# Altium schematic sheet Swich_PSU.SchDoc(Swich_PSU) (continued)
## Library data of the parts (continued)
D7 = CMP-0549-00004-1: Schottky Barrier Rectifier, 40 V Maximum DC Blocking Voltage, -65 to 125 degC, 2-Pin SMA Case, RoHS; Manufacturer: Fairchild/ON Semiconductor; Current - Average Rectified (Io): 1A; Current - Reverse Leakage @ Vr: 200µA @ 50V; LHADescription: DIODE SCHOTTKY 50V 1A SMA; Speed: Fast Recovery =< 500ns, > 200mA (Io); Type: DIODE; Voltage - DC Reverse (Vr) (Max): 50V; Voltage - Forward (Vf) (Max) @ If: 700mV @ 1A; Capacitance @ Vr, F: -; Category: Discrete Semiconductor Products; Component Kind: Standard; ComponentLink1Description: https://www.fairchildsemi.com/datasheets/SS/SS12.pdf; ComponentLink1URL: https://www.fairchildsemi.com/datasheets/SS/SS12.pdf; Diode Type: Schottky; Library Name: LHA_LIB_Generic.SchLib; Manufacturer Part Number: SS15; Mounting Type: Surface Mount; Operating Temperature - Junction: -65°C ~ 125°C; PackageCase: DO-214AC, SMA; Packaging: Cut Tape (CT); Part Description: DIODE SCHOTTKY 50V 1A SMA; Part Status: Active; Pricing 1: 1=0.42, 10=0.338, 100=0.2302, 500=0.17262, 1000=0.12947 (USD); RoHS: RoHS Compliant; Stock 1: 4068; Supplier 1: Digi-Key; Supplier Device Package: SMA (DO-214AC); Supplier Part Number 1: SS15FSCT-ND
L1 = INDUCTOR5: Power Inductor; Manufacturer: Abracon LLC; Current Rating: 1.1A; DC Resistance (DCR): 300 mOhm Max; Inductance: 33µH; LHADescription: FIXED IND 33UH 0.30OHM 1.10A; Shielding: Unshielded; Tolerance: ±10%; Type: MAG; Category: Inductors, Coils, Chokes; Component Kind: Standard; ComponentLink1Description: http://www.abracon.com/Magnetics/power/ASPI-0503H.pdf; ComponentLink1URL: http://www.abracon.com/Magnetics/power/ASPI-0503H.pdf; Current - Saturation: -; Frequency - Self Resonant: -; Height - Seated (Max): 0.138" (3.50mm); Manufacturer Part Number: PI0503-330M; Material - Core: -; Mounting Type: Surface Mount; Operating Temperature: -40°C ~ 125°C; PackageCase: Nonstandard; Packaging: Tape & Reel (TR); Part Description: FIXED IND 33UH 0.30OHM 1.10A; Part Status: Active; Pricing 1: 2000=0.1887, 4000=0.1776, 6000=0.17205, 10000=0.1665, 50000=0.1554 (USD); Q @ Freq: -; Ratings: -; RoHS: RoHS Compliant; Size / Dimension: 0.228" L x 0.205" W (5.80mm x 5.20mm); Stock 1: 0; Supplier 1: Digi-Key; Supplier Device Package: -; Supplier Part Number 1: 535-13295-2-ND
L4 = INDUCTOR: Inductors, Coils, Chokes; Manufacturer: Bourns Inc.; Current Rating: 5.5A; DC Resistance (DCR): 25 mOhm Max; Frequency - Self Resonant: 79MHz; Frequency - Test: 7.96MHz; Inductance: 3.3µH; LHADescription: FIXED IND 3.3UH 5.5A 25 MOHM TH; Material - Core: Ferrite; Q @ Freq: 20 @ 7.96MHz; Shielding: Unshielded; Tolerance: ±10%; Type: IND; Category: Inductors, Coils, Chokes; Component Kind: Standard; ComponentLink1Description: http://www.bourns.com/data/global/pdfs/rl622_series.pdf; ComponentLink1URL: http://www.bourns.com/data/global/pdfs/rl622_series.pdf; ComponentLink2Description: http://www.bourns.com/docs/Product-Datasheets/rl622_series.pdf; ComponentLink2URL: http://www.bourns.com/docs/Product-Datasheets/rl622_series.pdf; Current - Saturation: -; Height - Seated (Max): 0.433" (11.00mm); Library Name: LHA_LIB.SchLib; Manufacturer Part Number: RL622-3R3K-RC; Mounting Type: Through Hole; Operating Temperature: -55°C ~ 105°C; PackageCase: Radial; Packaging: Bulk; Part Description: FIXED IND 3.3UH 5.5A 25 MOHM TH; Part Status: Active; Pricing: 1=0.68, 10=0.591, 25=0.5484, 50=0.464, 100=0.4219, 250=0.39656, 500=0.35438, 1000=0.29925 (USD); Pricing 1: 1=0.83, 10=0.729, 100=0.5206, 500=0.43732, 1000=0.35403, 2500=0.3332 (USD); Ratings: -; RoHS: RoHS Compliant; Size / Dimension: 0.315" Dia x 0.244" H (8.00mm x 6.20mm); Stock: 4399; Stock 1: 2539; Supplier 1: Digi-Key; Supplier Device Package: -; Supplier Part Number 1: M9978-ND
R1 R11 = RES1: Resistor 1%; Manufacturer: Vishay Dale; Manufacturer Part Number: CRCW120615M0JPEAHR; Part Description: RES 15MR ±5% 1206 250mW; LHADescription: RES SMD 15M OHM 5% 1/4W 1206; Parameter 1: Part Description; Power (Watts): 0.25W, 1/4W; Power Rating: 250mW; Temperature Coefficient: ±500ppm/°C; Tolerance: ±5%; Voltage - Rated: 200V; Case Code (Imperial): 1206; Case Code (Metric): 3216; Category: Resistors; Component Kind: Standard; ComponentLink1Description: http://www.vishay.com/docs/20011/dcrcwhr.pdf; ComponentLink1URL: http://www.vishay.com/docs/20011/dcrcwhr.pdf; ComponentLink2Description: https://datasheet.ciiva.com/9039/dcrcwhe3-9039045.pdf?src-supplier=Avnet; ComponentLink2URL: https://datasheet.ciiva.com/9039/dcrcwhe3-9039045.pdf?src-supplier=Avnet; ComponentLink3Description: https://datasheet.ciiva.com/5542/dcrcwe3-109170-5542431.pdf?src-supplier=Mouser; ComponentLink3URL: https://datasheet.ciiva.com/5542/dcrcwe3-109170-5542431.pdf?src-supplier=Mouser; Composition: Thick Film; Contact Plating: Tin; Depth: 1.6mm; Failure Rate: -; Features: -; Height: 0.55mm; Height - Seated (Max): 0.024" (0.60mm); Length: 3.2mm; Library Name: LHA_LIB.SchLib; Max Operating Temperature: 155°C; Military Standard: Not; Min Operating Temperature: -55°C; Operating Temperature: -55°C ~ 155°C; PackageCase: 1206; Packaging: Tape & Reel (TR); Part Status: Active; Pricing 1: 5000=0.09315, 10000=0.09108, 25000=0.08798, 50000=0.087 (USD); Pricing 2: 1=0.59000, 10=0.45500, 100=0.19140, 1000=0.10560, 2500=0.09900 (USD); Radiation Hardening: No; Resistance: 15MR; RoHS: RoHS Compliant; Size / Dimension: 0.126" L x 0.063" W (3.20mm x 1.60mm); Stock 1: 5000; Stock 2: 3104; Supplier 1: Digi-Key; Supplier 2: Digi-Key; Supplier Device Package: 1206; Supplier Part Number 1: 541-15MALTR-ND; Supplier Part Number 2: 541-15MALDKR-ND; Weight: 0.000353oz; Width: 1.6mm
R5 = RES1: Resistor 1%; Manufacturer: Yageo; Manufacturer Part Number: RC1206FR-071KL; Part Description: RES 1kR ±1% 1206 250mW; LHADescription: RES SMD 1K OHM 1% 1/4W 1206; Power (Watts): 0.25W, 1/4W; Power Rating: 250mW; Temperature Coefficient: ±100ppm/°C; Voltage - Rated: 200V; Case Code (Imperial): 1206; Case Code (Metric): 3216; Category: Resistors; Component Kind: Standard; ComponentLink1Description: http://www.yageo.com/documents/recent/PYu-RC_Group_51_RoHS_L_7.pdf; ComponentLink1URL: http://www.yageo.com/documents/recent/PYu-RC_Group_51_RoHS_L_7.pdf; ComponentLink2Description: https://datasheet.ciiva.com/11808/0900766b80c6630a-11808217.pdf?src-supplier=RSComponents; ComponentLink2URL: https://datasheet.ciiva.com/11808/0900766b80c6630a-11808217.pdf?src-supplier=RSComponents; ComponentLink3Description: https://datasheet.ciiva.com/29181/402540-da-01-en-smd-widerstand-chip1206-1-kohm-29181462.pdf?src-supplier=Conrad; ComponentLink3URL: https://datasheet.ciiva.com/29181/402540-da-01-en-smd-widerstand-chip1206-1-kohm-29181462.pdf?src-supplier=Conrad; ComponentLink4Description: https://datasheet.ciiva.com/46445/444357-46445708.pdf?src-supplier=Element14; ComponentLink4URL: https://datasheet.ciiva.com/46445/444357-46445708.pdf?src-supplier=Element14; Composition: Thick Film; Depth: 1.6mm; Failure Rate: -; Features: Moisture Resistant; Height: 0.55mm; Height - Seated (Max): 0.026" (0.65mm); Lead Free: Lead Free; Length: 3.1mm; Library Name: LHA_LIB.SchLib; Max Operating Temperature: 155°C; Military Standard: Not; Min Operating Temperature: -55°C; Operating Temperature: -55°C ~ 155°C; PackageCase: 1206; Packaging: Tape & Reel (TR); Part Status: Active; Pricing 1: 5000=0.00851, 10000=0.00739, 25000=0.00650, 50000=0.00596, 125000=0.00584 (USD); REACH SVHC: Unknown; Resistance: 1kR; RoHS: RoHS Compliant; Size / Dimension: 0.122" L x 0.063" W (3.10mm x 1.60mm); Stock 1: 10566944; Supplier 1: Digi-Key; Supplier Device Package: 1206; Supplier Part Number 1: 311-1.00KFRTR-ND; Termination: SMD/SMT; Tolerance: ±1%
R7 R8 = RES1: Resistor 1%; Manufacturer: TE Connectivity; Manufacturer Part Number: RR02J43KTB; Part Description: RES 43kR 5% Axial 2W; LHADescription: Res Metal Film 43K Ohm 5% 2W ±300ppm/°C Conformal AXL Thru-Hole Ammo Pack; Number of Resistors: 1; Parameter 1: Part Description; Power Rating: 2W; Temperature Coefficient: 300ppm/°C; Tolerance: 5%; Voltage Rated: 500V; Category: Resistors; Component Kind: Standard; ComponentLink1Description: http://industrial.panasonic.com/www-cgi/jvcr13pz.cgi?E+PZ+3+AOA0018+ERG2SJ243+7+WW; ComponentLink1URL: http://industrial.panasonic.com/www-cgi/jvcr13pz.cgi?E+PZ+3+AOA0018+ERG2SJ243+7+WW; ComponentLink2Description: http://media.digikey.com/pdf/Data%20Sheets/Panasonic%20Resistors%20Thermistors%20PDFs/ERX_GxS_F_Series.pdf; ComponentLink2URL: http://media.digikey.com/pdf/Data%20Sheets/Panasonic%20Resistors%20Thermistors%20PDFs/ERX_GxS_F_Series.pdf; ComponentLink3Description: https://datasheet.ciiva.com/2710/yageolr-fmp-2013-2710734.pdf?src-supplier=Digi-Key; ComponentLink3URL: https://datasheet.ciiva.com/2710/yageolr-fmp-2013-2710734.pdf?src-supplier=Digi-Key; ComponentLink4Description: https://datasheet.ciiva.com/2710/yageolr-fmp-2013-2710735.pdf?src-supplier=Digi-Key; ComponentLink4URL: https://datasheet.ciiva.com/2710/yageolr-fmp-2013-2710735.pdf?src-supplier=Digi-Key; ComponentLink5Description: http://www.mouser.com/ds/2/418/NG_DS_3-1773449-2_E-725785.pdf?src-supplier=Mouser; ComponentLink5URL: http://www.mouser.com/ds/2/418/NG_DS_3-1773449-2_E-725785.pdf?src-supplier=Mouser; ComponentLink6Description: http://datasheet.octopart.com/RR02J43KTB-Tyco-Electronics-datasheet-11120060.pdf?src-supplier=TE+Connectivity; ComponentLink6URL: http://datasheet.octopart.com/RR02J43KTB-Tyco-Electronics-datasheet-11120060.pdf?src-supplier=TE+Connectivity; ComponentLink7Description: http://datasheet.octopart.com/RR02J43KTB-TE-Connectivity-datasheet-14742579.pdf?src-supplier=TE+Connectivity; ComponentLink7URL: http://datasheet.octopart.com/RR02J43KTB-TE-Connectivity-datasheet-14742579.pdf?src-supplier=TE+Connectivity; ComponentLink8Description: http://datasheet.octopart.com/RR02J43KTB-TE-Connectivity-datasheet-12070016.pdf?src-supplier=TE+Connectivity; ComponentLink8URL: http://datasheet.octopart.com/RR02J43KTB-TE-Connectivity-datasheet-12070016.pdf?src-supplier=TE+Connectivity; ComponentLink9Description: http://datasheet.octopart.com/RR02J43KTB-TE-Connectivity-datasheet-11120284.pdf?src-supplier=TE+Connectivity; ComponentLink9URL: http://datasheet.octopart.com/RR02J43KTB-TE-Connectivity-datasheet-11120284.pdf?src-supplier=TE+Connectivity; ComponentLink10Description: http://datasheet.octopart.com/RR02J43KTB-TE-Connectivity-datasheet-62056503.pdf?src-supplier=Newark; ComponentLink10URL: http://datasheet.octopart.com/RR02J43KTB-TE-Connectivity-datasheet-62056503.pdf?src-supplier=Newark; ComponentLink11Description: http://sigma.octopart.com/113924001/technical_drawing/TE-Connectivity-RR02J43KTB.pdf?src-supplier=TE+Connectivity; ComponentLink11URL: http://sigma.octopart.com/113924001/technical_drawing/TE-Connectivity-RR02J43KTB.pdf?src-supplier=TE+Connectivity; Composition: Power resistor; Diameter: 3.5mm; ELV: Compliant; Length: 9mm; Library Name: LHA_LIB.SchLib; Max Operating Temperature: 155°C; Min Operating Temperature: -55°C; Mount: Axial; Number of Terminations: 2; Package Quantity: 1000; PackageCase: Axial; Packaging: Ammo Pack; Pricing 1: 1000=0.04585, 2000=0.04203, 5000=0.03821, 10000=0.03566, 25000=0.03388, 50000=0.03319, 100000=0.03247 (USD); Resistance: 43kR; Stock 1: 3000; Supplier 1: Digi-Key; Supplier Part Number 1: A138330TB-ND; Termination: Axial
R9 = RES1: Resistor 1%; Manufacturer: Stackpole Electronics; Manufacturer Part Number: RMCF1206FT5R10; Part Description: RES 5.1R 1% 1206 250mW; LHADescription: RES SMD 5.1 OHM 1% 1/4W 1206; Power Rating: 250mW; Temperature Coefficient: 200ppm/°C; Category: Resistors; Component Kind: Standard; ComponentLink1Description: http://www.yageo.com/documents/recent/PYu-RC_Group_51_RoHS_L_7.pdf; ComponentLink1URL: http://www.yageo.com/documents/recent/PYu-RC_Group_51_RoHS_L_7.pdf; ComponentLink2Description: https://www.seielect.com/catalog/SEI-RMCF_RMCP.pdf?src-supplier=Avnet; ComponentLink2URL: https://www.seielect.com/catalog/SEI-RMCF_RMCP.pdf?src-supplier=Avnet; Composition: Thick Film; Contact Plating: Tin,Matte; Features: Automotive AEC-Q200; Height: 0.028inch; Length: 0.126inch; Library Name: LHA_LIB.SchLib; Max Operating Temperature: 155°C; Min Operating Temperature: -55°C; Mount: Surface Mount; Number of Terminations: 2; PackageCase: 1206; Packaging: Tape and Reel; Pricing 1: 5000=0.00570, 10000=0.00495, 25000=0.00435, 50000=0.00408 (USD); Radiation Hardening: No; Resistance: 5.1R; RoHS: Yes; Stock 1: 10000; Supplier 1: Digi-Key; Supplier Part Number 1: RMCF1206FT5R10TR-ND; Tolerance: 1%; Width: 0.063inch
R10 R14 = RES1: Resistor 1%; Manufacturer: Vishay; Manufacturer Part Number: CRCW12062M20FKEA; Part Description: RES 2.2MR 1% 1206 250mW; LHADescription: RES SMD 2.2M OHM 1% 1/4W 1206; Max Power Dissipation: 250mW; Temperature Coefficient: 100ppm/°C; Voltage - Rated: 200V; Case Code (Imperial): 1206; Case Code (Metric): 3216; Category: Resistors; Component Kind: Standard; ComponentLink1Description: http://www.yageo.com/documents/recent/PYu-RC_Group_51_RoHS_L_7.pdf; ComponentLink1URL: http://www.yageo.com/documents/recent/PYu-RC_Group_51_RoHS_L_7.pdf; ComponentLink2Description: https://datasheet.ciiva.com/11808/0900766b80c6630a-11808948.pdf?src-supplier=RSComponents; ComponentLink2URL: https://datasheet.ciiva.com/11808/0900766b80c6630a-11808948.pdf?src-supplier=RSComponents; ComponentLink3Description: https://datasheet.ciiva.com/2460/pyu-rc1206-51-rohs-l-4-2460252.pdf?src-supplier=Digi-Key; ComponentLink3URL: https://datasheet.ciiva.com/2460/pyu-rc1206-51-rohs-l-4-2460252.pdf?src-supplier=Digi-Key; ComponentLink4Description: https://datasheet.ciiva.com/2460/pyu-rc1206-51-rohs-l-4-2460253.pdf?src-supplier=Digi-Key; ComponentLink4URL: https://datasheet.ciiva.com/2460/pyu-rc1206-51-rohs-l-4-2460253.pdf?src-supplier=Digi-Key; ComponentLink5Description: https://datasheet.ciiva.com/17483/pyu-rc1206-51-rohs-l-4-17483917.pdf?src-supplier=Future+Electronics; ComponentLink5URL: https://datasheet.ciiva.com/17483/pyu-rc1206-51-rohs-l-4-17483917.pdf?src-supplier=Future+Electronics; ComponentLink6Description: https://datasheet.ciiva.com/2460/pyu-rc1206-51-rohs-l-4-2460254.pdf?src-supplier=Digi-Key; ComponentLink6URL: https://datasheet.ciiva.com/2460/pyu-rc1206-51-rohs-l-4-2460254.pdf?src-supplier=Digi-Key; ComponentLink7Description: https://datasheet.ciiva.com/11811/0900766b80d98b5e-11811616.pdf?src-supplier=RSComponents; ComponentLink7URL: https://datasheet.ciiva.com/11811/0900766b80d98b5e-11811616.pdf?src-supplier=RSComponents; ComponentLink8Description: https://datasheet.ciiva.com/22682/1700796-22682681.pdf?src-supplier=Element14; ComponentLink8URL: https://datasheet.ciiva.com/22682/1700796-22682681.pdf?src-supplier=Element14; ComponentLink9Description: https://datasheet.ciiva.com/2469/dcrcwe3-2469578.pdf?src-supplier=Digi-Key; ComponentLink9URL: https://datasheet.ciiva.com/2469/dcrcwe3-2469578.pdf?src-supplier=Digi-Key; ComponentLink10Description: https://datasheet.ciiva.com/2469/dcrcwe3-2469576.pdf?src-supplier=Digi-Key; ComponentLink10URL: https://datasheet.ciiva.com/2469/dcrcwe3-2469576.pdf?src-supplier=Digi-Key; ComponentLink11Description: https://datasheet.ciiva.com/16760/70201119-16760601.pdf?src-supplier=Allied+Electronics; ComponentLink11URL: https://datasheet.ciiva.com/16760/70201119-16760601.pdf?src-supplier=Allied+Electronics; ComponentLink12Description: https://datasheet.ciiva.com/17201/dcrcwe3-17201719.pdf?src-supplier=Avnet+Europe; ComponentLink12URL: https://datasheet.ciiva.com/17201/dcrcwe3-17201719.pdf?src-supplier=Avnet+Europe; ComponentLink13Description: https://datasheet.ciiva.com/2469/dcrcwe3-2469577.pdf?src-supplier=Digi-Key; ComponentLink13URL: https://datasheet.ciiva.com/2469/dcrcwe3-2469577.pdf?src-supplier=Digi-Key; ComponentLink14Description: https://datasheet.ciiva.com/7801/1697337-7801226.pdf?src-supplier=Element14; ComponentLink14URL: https://datasheet.ciiva.com/7801/1697337-7801226.pdf?src-supplier=Element14; ComponentLink15Description: https://datasheet.ciiva.com/22869/70201119-22869466.pdf?src-supplier=Allied+Electronics; ComponentLink15URL: https://datasheet.ciiva.com/22869/70201119-22869466.pdf?src-supplier=Allied+Electronics; ComponentLink16Description: https://datasheet.ciiva.com/26316/fld-crcw-e3-data-en-26316490.pdf?src-supplier=Distrelec; ComponentLink16URL: https://datasheet.ciiva.com/26316/fld-crcw-e3-data-en-26316490.pdf?src-supplier=Distrelec; ComponentLink17Description: https://datasheet.ciiva.com/16430/dcrcw-16430379.pdf?src-supplier=Future+Electronics; ComponentLink17URL: https://datasheet.ciiva.com/16430/dcrcw-16430379.pdf?src-supplier=Future+Electronics; ComponentLink18Description: https://datasheet.ciiva.com/5552/dcrcwe3-109170-5552556.pdf?src-supplier=Mouser; ComponentLink18URL: https://datasheet.ciiva.com/5552/dcrcwe3-109170-5552556.pdf?src-supplier=Mouser; Composition: Thick Film; Contact Plating: Tin; Depth: 1.6mm; Features: Automotive AEC-Q200; Height: 0.55mm; Lead Free: Lead Free; Length: 3.2mm; Library Name: LHA_LIB.SchLib; Max Operating Temperature: 155°C; Military Standard: Not; Min Operating Temperature: -55°C; Number of Terminations: 2; Package Quantity: 10; PackageCase: 1206; Packaging: Tape and Reel; Power Rating: 250mW; Pricing 1: 1=0.10000, 10=0.07600, 100=0.02930, 1000=0.01287, 2500=0.01112 (USD); Radiation Hardening: No; REACH SVHC: Unknown; Resistance: 2.2MR; RoHS: Yes; Stock 1: 4851; Supplier 1: Digi-Key; Supplier Part Number 1: 541-2.20MFDKR-ND; Termination: SMD/SMT; Tolerance: 1%; Voltage Rating (DC): 200V; Weight: 0.000353oz; Width: 0.063inch
R12 = RES1: Resistor 1%; Manufacturer: Yageo; Manufacturer Part Number: RC1206FR-077K5L; Part Description: RES 7.5kR 1% 1206 250mW; LHADescription: RES SMD 7.5K OHM 1% 1/4W 1206; Max Power Dissipation: 250mW; Temperature Coefficient: 100ppm/°C; Voltage Rated: 200V; Case Code (Imperial): 1206; Case Code (Metric): 3216; Category: Resistors; Component Kind: Standard; ComponentLink1Description: https://datasheet.ciiva.com/48439/rk73h-series-eng-jap-tds-48439211.pdf?src-supplier=Distrelec; ComponentLink1URL: https://datasheet.ciiva.com/48439/rk73h-series-eng-jap-tds-48439211.pdf?src-supplier=Distrelec; ComponentLink2Description: https://datasheet.ciiva.com/5557/rk73h-5557179.pdf?src-supplier=Mouser; ComponentLink2URL: https://datasheet.ciiva.com/5557/rk73h-5557179.pdf?src-supplier=Mouser; ComponentLink3Description: https://datasheet.ciiva.com/12706/pyu-rt-1-to-0-05-rohs-l-4-12706181.pdf?src-supplier=Mouser; ComponentLink3URL: https://datasheet.ciiva.com/12706/pyu-rt-1-to-0-05-rohs-l-4-12706181.pdf?src-supplier=Mouser; ComponentLink4Description: https://datasheet.ciiva.com/2566/pyu-rt-1-to-0-05-rohs-l-5-2566685.pdf?src-supplier=Digi-Key; ComponentLink4URL: https://datasheet.ciiva.com/2566/pyu-rt-1-to-0-05-rohs-l-5-2566685.pdf?src-supplier=Digi-Key; ComponentLink5Description: https://datasheet.ciiva.com/8894/pyu-rt-1-to-0-05-rohs-l-4-8894699.pdf?src-supplier=Avnet; ComponentLink5URL: https://datasheet.ciiva.com/8894/pyu-rt-1-to-0-05-rohs-l-4-8894699.pdf?src-supplier=Avnet; ComponentLink6Description: https://datasheet.ciiva.com/11808/0900766b80c6630a-11808965.pdf?src-supplier=RSComponents; ComponentLink6URL: https://datasheet.ciiva.com/11808/0900766b80c6630a-11808965.pdf?src-supplier=RSComponents; ComponentLink7Description: https://datasheet.ciiva.com/2460/pyu-rc1206-51-rohs-l-4-2460562.pdf?src-supplier=Digi-Key; ComponentLink7URL: https://datasheet.ciiva.com/2460/pyu-rc1206-51-rohs-l-4-2460562.pdf?src-supplier=Digi-Key; ComponentLink8Description: https://datasheet.ciiva.com/2460/pyu-rc1206-51-rohs-l-4-2460561.pdf?src-supplier=Digi-Key; ComponentLink8URL: https://datasheet.ciiva.com/2460/pyu-rc1206-51-rohs-l-4-2460561.pdf?src-supplier=Digi-Key; ComponentLink9Description: https://datasheet.ciiva.com/2460/pyu-rc1206-51-rohs-l-4-2460563.pdf?src-supplier=Digi-Key; ComponentLink9URL: https://datasheet.ciiva.com/2460/pyu-rc1206-51-rohs-l-4-2460563.pdf?src-supplier=Digi-Key; ComponentLink10Description: https://datasheet.ciiva.com/17051/pyu-rc1206-51-rohs-l-4-17051432.pdf?src-supplier=Future+Electronics; ComponentLink10URL: https://datasheet.ciiva.com/17051/pyu-rc1206-51-rohs-l-4-17051432.pdf?src-supplier=Future+Electronics; Composition: Thick Film; Depth: 1.6mm; Features: Moisture Resistant; Height: 0.55mm; Lead Free: Lead Free; Length: 3.1mm; Library Name: LHA_LIB.SchLib; Max Operating Temperature: 155°C; Military Standard: Not; Min Operating Temperature: -55°C; Mount: Surface Mount; Number of Terminations: 2; Package Quantity: 1; PackageCase: 1206; Packaging: Tape and Reel; Power Rating: 250mW; Pricing 1: 1=0.10000, 10=0.07100, 100=0.02910, 1000=0.01306, 2500=0.01134 (USD); Resistance: 7.5kR; RoHS: Yes; Stock 1: 1; Supplier 1: Digi-Key; Supplier Part Number 1: 311-7.50KFRCT-ND; Termination: SMD/SMT; Tolerance: 1%; Weight: 0.000571oz; Width: 0.063inch
R13 = RES1: Resistor 1%; Manufacturer: Vishay Dale; Manufacturer Part Number: CRCW12062K74FKTA; Part Description: RES 2.74kR 1% 1206 250mW; LHADescription: RES SMD 2.74K OHM 1% 1/4W 1206; Max Power Dissipation: 250mW; Temperature Coefficient: 100ppm/°C; Voltage Rated: 200V; Case Code (Imperial): 1206; Case Code (Metric): 3216; Category: Resistors; Component Kind: Standard; ComponentLink1Description: http://www.vishay.com/doc?20035; ComponentLink1URL: http://www.vishay.com/doc?20035; ComponentLink2Description: http://www.vishay.com/docs/20035/dcrcwe3.pdf; ComponentLink2URL: http://www.vishay.com/docs/20035/dcrcwe3.pdf; ComponentLink3Description: https://datasheet.ciiva.com/11811/0900766b80d98b26-11811261.pdf?src-supplier=RSComponents; ComponentLink3URL: https://datasheet.ciiva.com/11811/0900766b80d98b26-11811261.pdf?src-supplier=RSComponents; ComponentLink4Description: https://datasheet.ciiva.com/2525/dcrcwe3-2525761.pdf?src-supplier=Digi-Key; ComponentLink4URL: https://datasheet.ciiva.com/2525/dcrcwe3-2525761.pdf?src-supplier=Digi-Key; ComponentLink5Description: https://datasheet.ciiva.com/2525/dcrcwe3-2525762.pdf?src-supplier=Digi-Key; ComponentLink5URL: https://datasheet.ciiva.com/2525/dcrcwe3-2525762.pdf?src-supplier=Digi-Key; ComponentLink6Description: https://datasheet.ciiva.com/2525/dcrcwe3-2525763.pdf?src-supplier=Digi-Key; ComponentLink6URL: https://datasheet.ciiva.com/2525/dcrcwe3-2525763.pdf?src-supplier=Digi-Key; ComponentLink7Description: https://datasheet.ciiva.com/5562/dcrcwe3-109170-5562039.pdf?src-supplier=Mouser; ComponentLink7URL: https://datasheet.ciiva.com/5562/dcrcwe3-109170-5562039.pdf?src-supplier=Mouser; ComponentLink8Description: https://datasheet.ciiva.com/3258/dcrcwe3-3258456.pdf?src-supplier=Avnet; ComponentLink8URL: https://datasheet.ciiva.com/3258/dcrcwe3-3258456.pdf?src-supplier=Avnet; ComponentLink9Description: https://datasheet.ciiva.com/16779/70201119-16779133.pdf?src-supplier=Allied+Electronics; ComponentLink9URL: https://datasheet.ciiva.com/16779/70201119-16779133.pdf?src-supplier=Allied+Electronics; ComponentLink10Description: https://datasheet.ciiva.com/22869/70201119-22869246.pdf?src-supplier=Allied+Electronics; ComponentLink10URL: https://datasheet.ciiva.com/22869/70201119-22869246.pdf?src-supplier=Allied+Electronics; ComponentLink11Description: https://datasheet.ciiva.com/3258/dcrcwe3-3258457.pdf?src-supplier=Avnet; ComponentLink11URL: https://datasheet.ciiva.com/3258/dcrcwe3-3258457.pdf?src-supplier=Avnet; ComponentLink12Description: https://datasheet.ciiva.com/7841/1697337-7841849.pdf?src-supplier=Element14; ComponentLink12URL: https://datasheet.ciiva.com/7841/1697337-7841849.pdf?src-supplier=Element14; ComponentLink13Description: https://datasheet.ciiva.com/7841/1697337-7841850.pdf?src-supplier=Element14; ComponentLink13URL: https://datasheet.ciiva.com/7841/1697337-7841850.pdf?src-supplier=Element14; ComponentLink14Description: https://datasheet.ciiva.com/7841/1697337-7841660.pdf?src-supplier=Element14; ComponentLink14URL: https://datasheet.ciiva.com/7841/1697337-7841660.pdf?src-supplier=Element14; ComponentLink15Description: https://datasheet.ciiva.com/17199/dcrcwe3-17199871.pdf?src-supplier=Avnet+Europe; ComponentLink15URL: https://datasheet.ciiva.com/17199/dcrcwe3-17199871.pdf?src-supplier=Avnet+Europe; ComponentLink16Description: https://datasheet.ciiva.com/2568/dcrcw-2568679.pdf?src-supplier=Digi-Key; ComponentLink16URL: https://datasheet.ciiva.com/2568/dcrcw-2568679.pdf?src-supplier=Digi-Key; ComponentLink17Description: https://datasheet.ciiva.com/9085/dcrcw-9085053.pdf?src-supplier=Avnet; ComponentLink17URL: https://datasheet.ciiva.com/9085/dcrcw-9085053.pdf?src-supplier=Avnet; Composition: Thick Film; Contact Plating: Tin,Lead; Features: Automotive AEC-Q200; Height: 0.024inch; Lead Free: Contains Lead; Length: 0.126inch; Library Name: LHA_LIB.SchLib; Max Operating Temperature: 155°C; Min Operating Temperature: -55°C; Number of Pins: 2; Number of Terminations: 2; Package Quantity: 5000; PackageCase: 1206; Packaging: Tape and Reel; Power Dissipation: 250mW; Power Rating: 250mW; Pricing 1: 5000=0.01697, 10000=0.01522, 25000=0.01397, 50000=0.01357 (USD); Radiation Hardening: No; REACH SVHC: Unknown; Resistance: 2.74kR; RoHS: Yes; Stock 1: 0; Supplier 1: Digi-Key; Supplier Part Number 1: CRCW12062K74FKTA-ND; Termination: SMD/SMT; Tolerance: 1%; Weight: 0.000353oz; Width: 0.063inch
R16 = RES1: Manufacturer: Yageo; Manufacturer Part Number: RC0805FR-071KL; Part Description: RES 1kR 1% 0805 125mW; LHADescription: RES SMD 1K OHM 1% 1/8W 0805; Max Power Dissipation: 125mW; Parameter 1: Part Description; Resistance: 1kR; Temperature Coefficient: 100ppm/°C; Tolerance: 1%; Voltage Rated: 150V; Case Code (Imperial): 0805; Case Code (Metric): 2012; Category: Resistors; Component Kind: Standard; ComponentLink1Description: http://www.yageo.com/documents/recent/PYu-RC_Group_51_RoHS_L_7.pdf; ComponentLink1URL: http://www.yageo.com/documents/recent/PYu-RC_Group_51_RoHS_L_7.pdf; ComponentLink2Description: https://datasheet.ciiva.com/2456/pyu-r-int-thick-7-2456976.pdf?src-supplier=Digi-Key; ComponentLink2URL: https://datasheet.ciiva.com/2456/pyu-r-int-thick-7-2456976.pdf?src-supplier=Digi-Key; ComponentLink3Description: https://datasheet.ciiva.com/2456/pyu-r-int-thick-7-2456977.pdf?src-supplier=Digi-Key; ComponentLink3URL: https://datasheet.ciiva.com/2456/pyu-r-int-thick-7-2456977.pdf?src-supplier=Digi-Key; ComponentLink4Description: https://datasheet.ciiva.com/7941/1686128-7941719.pdf?src-supplier=Element14; ComponentLink4URL: https://datasheet.ciiva.com/7941/1686128-7941719.pdf?src-supplier=Element14; ComponentLink5Description: https://datasheet.ciiva.com/16850/rc0805-16850409.pdf?src-supplier=Future+Electronics; ComponentLink5URL: https://datasheet.ciiva.com/16850/rc0805-16850409.pdf?src-supplier=Future+Electronics; ComponentLink6Description: https://datasheet.ciiva.com/46385/444164-46385020.pdf?src-supplier=Element14; ComponentLink6URL: https://datasheet.ciiva.com/46385/444164-46385020.pdf?src-supplier=Element14; ComponentLink7Description: https://datasheet.ciiva.com/2456/pyu-r-int-thick-7-2456975.pdf?src-supplier=Digi-Key; ComponentLink7URL: https://datasheet.ciiva.com/2456/pyu-r-int-thick-7-2456975.pdf?src-supplier=Digi-Key; Composition: Thick Film; Depth: 1.25mm; Description: DNP; Features: Moisture Resistant; Height: 0.024inch; Lead Free: Lead Free; Length: 0.079inch; Library Name: LHA_LIB.SchLib; Max Operating Temperature: 155°C; Military Standard: Not; Min Operating Temperature: -55°C; Number of Pins: 2; Number of Terminations: 2; Package Quantity: 1; PackageCase: 0805; Packaging: Tape and Reel; Power Rating: 125mW; Pricing 1: 5000=0.00431, 10000=0.00375, 25000=0.00329, 50000=0.00309 (USD); REACH SVHC: Unknown; RoHS: Yes; Stock 1: 26180000; Supplier 1: Digi-Key; Supplier Part Number 1: 311-1.00KCRTR-ND; Termination: SMD/SMT; Voltage Rating (DC): 150V; Width: 0.049inch
R17 = RES1: Resistor 1%; Manufacturer: Yageo; Manufacturer Part Number: RC1206FR-07137KL; Part Description: RES 137kR 1% 1206 250mW; LHADescription: RES SMD 137K OHM 1% 1/4W 1206; Max Power Dissipation: 250mW; Temperature Coefficient: 100ppm/°C; Voltage Rated: 200V; Case Code (Imperial): 1206; Case Code (Metric): 3216; Category: Resistors; Component Kind: Standard; ComponentLink1Description: http://industrial.panasonic.com/www-cgi/jvcr13pz.cgi?E+PZ+3+AOA0002+ERJ8ENF1373V+7+WW; ComponentLink1URL: http://industrial.panasonic.com/www-cgi/jvcr13pz.cgi?E+PZ+3+AOA0002+ERJ8ENF1373V+7+WW; ComponentLink2Description: https://industrial.panasonic.com/cdbs/www-data/pdf/RDA0000/AOA0000C304.pdf; ComponentLink2URL: https://industrial.panasonic.com/cdbs/www-data/pdf/RDA0000/AOA0000C304.pdf; ComponentLink3Description: https://datasheet.ciiva.com/16396/dcrcwe3-16396309.pdf?src-supplier=Future+Electronics; ComponentLink3URL: https://datasheet.ciiva.com/16396/dcrcwe3-16396309.pdf?src-supplier=Future+Electronics; ComponentLink4Description: https://datasheet.ciiva.com/2467/dcrcwe3-2467464.pdf?src-supplier=Digi-Key; ComponentLink4URL: https://datasheet.ciiva.com/2467/dcrcwe3-2467464.pdf?src-supplier=Digi-Key; ComponentLink5Description: https://datasheet.ciiva.com/2467/dcrcwe3-2467465.pdf?src-supplier=Digi-Key; ComponentLink5URL: https://datasheet.ciiva.com/2467/dcrcwe3-2467465.pdf?src-supplier=Digi-Key; ComponentLink6Description: https://datasheet.ciiva.com/2467/dcrcwe3-2467466.pdf?src-supplier=Digi-Key; ComponentLink6URL: https://datasheet.ciiva.com/2467/dcrcwe3-2467466.pdf?src-supplier=Digi-Key; ComponentLink7Description: https://datasheet.ciiva.com/22705/1697337-22705866.pdf?src-supplier=Element14; ComponentLink7URL: https://datasheet.ciiva.com/22705/1697337-22705866.pdf?src-supplier=Element14; ComponentLink8Description: https://datasheet.ciiva.com/7714/1697337-7714270.pdf?src-supplier=Element14; ComponentLink8URL: https://datasheet.ciiva.com/7714/1697337-7714270.pdf?src-supplier=Element14; ComponentLink9Description: https://datasheet.ciiva.com/7714/1697337-7714271.pdf?src-supplier=Element14; ComponentLink9URL: https://datasheet.ciiva.com/7714/1697337-7714271.pdf?src-supplier=Element14; ComponentLink10Description: https://datasheet.ciiva.com/2461/pyu-rc1206-51-rohs-l-4-2461227.pdf?src-supplier=Digi-Key; ComponentLink10URL: https://datasheet.ciiva.com/2461/pyu-rc1206-51-rohs-l-4-2461227.pdf?src-supplier=Digi-Key; ComponentLink11Description: https://datasheet.ciiva.com/2461/pyu-rc1206-51-rohs-l-4-2461228.pdf?src-supplier=Digi-Key; ComponentLink11URL: https://datasheet.ciiva.com/2461/pyu-rc1206-51-rohs-l-4-2461228.pdf?src-supplier=Digi-Key; ComponentLink12Description: https://datasheet.ciiva.com/2461/pyu-rc1206-51-rohs-l-4-2461229.pdf?src-supplier=Digi-Key; ComponentLink12URL: https://datasheet.ciiva.com/2461/pyu-rc1206-51-rohs-l-4-2461229.pdf?src-supplier=Digi-Key; ComponentLink13Description: https://datasheet.ciiva.com/17422/pyu-rc1206-51-rohs-l-4-17422830.pdf?src-supplier=Future+Electronics; ComponentLink13URL: https://datasheet.ciiva.com/17422/pyu-rc1206-51-rohs-l-4-17422830.pdf?src-supplier=Future+Electronics; Composition: Thick Film; Contact Plating: Tin,Matte; Depth: 1.6mm; Features: Moisture Resistant; Height: 0.026inch; Lead Free: Lead Free; Length: 3.1mm; Library Name: LHA_LIB.SchLib; Max Operating Temperature: 155°C; Military Standard: Not; Min Operating Temperature: -55°C; Number of Terminations: 2; Package Quantity: 1; PackageCase: 1206; Packaging: Tape and Reel; Power Rating: 250mW; Pricing 1: 5000=0.00851, 10000=0.00739 (USD); Radiation Hardening: No; Resistance: 137kR; RoHS: Yes; Stock 1: 70000; Supplier 1: Digi-Key; Supplier Part Number 1: 311-137KFRTR-ND; Termination: SMD/SMT; Tolerance: 1%; Width: 1.6mm
R18 = RES1: Resistor 1%; Manufacturer: Panasonic; Manufacturer Part Number: ERJ-8RQF6R8V; Part Description: RES 6.8R 1% 1206 250mW; LHADescription: Res Thick Film 1206 6.8 Ohm 1% 1/4W 200ppm/C Molded SMD SMD Punched Carrier T/R; Max Power Dissipation: 250mW; Temperature Coefficient: 200ppm/°C; Case Code (Imperial): 1206; Case Code (Metric): 3216; Category: Resistors; Component Kind: Standard; ComponentLink1Description: https://industrial.panasonic.com/cdbs/www-data/pdf/RDN0000/AOA0000C313.pdf; ComponentLink1URL: https://industrial.panasonic.com/cdbs/www-data/pdf/RDN0000/AOA0000C313.pdf; ComponentLink2Description: https://datasheet.ciiva.com/11818/0900766b80eefa12-11818594.pdf?src-supplier=RSComponents; ComponentLink2URL: https://datasheet.ciiva.com/11818/0900766b80eefa12-11818594.pdf?src-supplier=RSComponents; ComponentLink3Description: https://datasheet.ciiva.com/17212/aoa0000ce3-17212559.pdf?src-supplier=Avnet+Europe; ComponentLink3URL: https://datasheet.ciiva.com/17212/aoa0000ce3-17212559.pdf?src-supplier=Avnet+Europe; ComponentLink4Description: https://datasheet.ciiva.com/30242/6aoa0000ce3-30242294.pdf?src-supplier=Onlinecomponents.com; ComponentLink4URL: https://datasheet.ciiva.com/30242/6aoa0000ce3-30242294.pdf?src-supplier=Onlinecomponents.com; ComponentLink5Description: https://datasheet.ciiva.com/5868/391509-5868249.pdf?src-supplier=Farnell; ComponentLink5URL: https://datasheet.ciiva.com/5868/391509-5868249.pdf?src-supplier=Farnell; ComponentLink6Description: https://datasheet.ciiva.com/28688/391509-28688137.pdf?src-supplier=Element14; ComponentLink6URL: https://datasheet.ciiva.com/28688/391509-28688137.pdf?src-supplier=Element14; ComponentLink7Description: https://datasheet.ciiva.com/9194/aoa0000ce3-9194906.pdf?src-supplier=Avnet; ComponentLink7URL: https://datasheet.ciiva.com/9194/aoa0000ce3-9194906.pdf?src-supplier=Avnet; ComponentLink8Description: https://datasheet.ciiva.com/24071/aoa0000ce3-72189-24071431.pdf?src-supplier=Mouser; ComponentLink8URL: https://datasheet.ciiva.com/24071/aoa0000ce3-72189-24071431.pdf?src-supplier=Mouser; Composition: Thick Film; Contact Plating: Tin; Depth: 1.6mm; Height: 0.6mm; Lead Free: Lead Free; Length: 3.2mm; Library Name: LHA_LIB.SchLib; Max Operating Temperature: 125°C; Military Standard: Not; Min Operating Temperature: -55°C; Mount: Surface Mount; Number of Pins: 2; Number of Terminations: 2; Package Quantity: 5000; PackageCase: 1206; Packaging: Tape and Reel; Power Rating: 250mW; Pricing 1: 5000=0.04473, 10000=0.04175, 25000=0.03966, 50000=0.03886, 125000=0.03802 (USD); Radiation Hardening: No; REACH SVHC: No SVHC; Resistance: 6.8R; RoHS: Yes; Stock 1: 5000; Supplier 1: Digi-Key; Supplier Part Number 1: P6.8RTR-ND; Termination: SMD/SMT; Tolerance: 1%; Width: 1.6mm
R19 = RES1: Resistor 1%; Manufacturer: Vishay; Manufacturer Part Number: CRCW120610K2FKEA; Part Description: RES 10.2kR 1% 1206 250mW; LHADescription: RES SMD 10.2K OHM 1% 1/4W 1206; Max Power Dissipation: 250mW; Temperature Coefficient: 100ppm/°C; Voltage Rated: 200V; Case Code (Imperial): 1206; Case Code (Metric): 3216; Category: Resistors; Component Kind: Standard; ComponentLink1Description: http://www.yageo.com/documents/recent/PYu-RC1206_51_RoHS_L-4.pdf; ComponentLink1URL: http://www.yageo.com/documents/recent/PYu-RC1206_51_RoHS_L-4.pdf; ComponentLink2Description: http://www.yageo.com/documents/recent/PYu-RC_Group_51_RoHS_L_7.pdf; ComponentLink2URL: http://www.yageo.com/documents/recent/PYu-RC_Group_51_RoHS_L_7.pdf; ComponentLink3Description: https://datasheet.ciiva.com/11810/0900766b80d98b26-11810883.pdf?src-supplier=RSComponents; ComponentLink3URL: https://datasheet.ciiva.com/11810/0900766b80d98b26-11810883.pdf?src-supplier=RSComponents; ComponentLink4Description: https://datasheet.ciiva.com/7663/1697337-7663915.pdf?src-supplier=Element14; ComponentLink4URL: https://datasheet.ciiva.com/7663/1697337-7663915.pdf?src-supplier=Element14; ComponentLink5Description: https://datasheet.ciiva.com/7663/1697337-7663916.pdf?src-supplier=Element14; ComponentLink5URL: https://datasheet.ciiva.com/7663/1697337-7663916.pdf?src-supplier=Element14; ComponentLink6Description: https://datasheet.ciiva.com/22674/1825492-22674606.pdf?src-supplier=Element14; ComponentLink6URL: https://datasheet.ciiva.com/22674/1825492-22674606.pdf?src-supplier=Element14; ComponentLink7Description: https://datasheet.ciiva.com/3258/dcrcwe3-3258031.pdf?src-supplier=Avnet; ComponentLink7URL: https://datasheet.ciiva.com/3258/dcrcwe3-3258031.pdf?src-supplier=Avnet; ComponentLink8Description: https://datasheet.ciiva.com/2496/dcrcwe3-2496241.pdf?src-supplier=Digi-Key; ComponentLink8URL: https://datasheet.ciiva.com/2496/dcrcwe3-2496241.pdf?src-supplier=Digi-Key; ComponentLink9Description: https://datasheet.ciiva.com/2496/dcrcwe3-2496242.pdf?src-supplier=Digi-Key; ComponentLink9URL: https://datasheet.ciiva.com/2496/dcrcwe3-2496242.pdf?src-supplier=Digi-Key; ComponentLink10Description: https://datasheet.ciiva.com/2496/dcrcwe3-2496243.pdf?src-supplier=Digi-Key; ComponentLink10URL: https://datasheet.ciiva.com/2496/dcrcwe3-2496243.pdf?src-supplier=Digi-Key; ComponentLink11Description: https://datasheet.ciiva.com/8337/dcrcwe3-8337525.pdf?src-supplier=Avnet; ComponentLink11URL: https://datasheet.ciiva.com/8337/dcrcwe3-8337525.pdf?src-supplier=Avnet; ComponentLink12Description: https://datasheet.ciiva.com/5542/dcrcwe3-109170-5542214.pdf?src-supplier=Mouser; ComponentLink12URL: https://datasheet.ciiva.com/5542/dcrcwe3-109170-5542214.pdf?src-supplier=Mouser; ComponentLink13Description: https://datasheet.ciiva.com/22702/1697337-22702902.pdf?src-supplier=Element14; ComponentLink13URL: https://datasheet.ciiva.com/22702/1697337-22702902.pdf?src-supplier=Element14; ComponentLink14Description: https://datasheet.ciiva.com/16204/dcrcwe3-16204135.pdf?src-supplier=Future+Electronics; ComponentLink14URL: https://datasheet.ciiva.com/16204/dcrcwe3-16204135.pdf?src-supplier=Future+Electronics; ComponentLink15Description: https://datasheet.ciiva.com/16852/dcrcwe3-16852825.pdf?src-supplier=Avnet+Europe; ComponentLink15URL: https://datasheet.ciiva.com/16852/dcrcwe3-16852825.pdf?src-supplier=Avnet+Europe; ComponentLink16Description: https://datasheet.ciiva.com/2469/dcrcwe3-2469446.pdf?src-supplier=Digi-Key; ComponentLink16URL: https://datasheet.ciiva.com/2469/dcrcwe3-2469446.pdf?src-supplier=Digi-Key; ComponentLink17Description: https://datasheet.ciiva.com/16514/dcrcwe3-16514745.pdf?src-supplier=Avnet+Asia; ComponentLink17URL: https://datasheet.ciiva.com/16514/dcrcwe3-16514745.pdf?src-supplier=Avnet+Asia; ComponentLink18Description: https://datasheet.ciiva.com/9049/dcrcwe3-9049146.pdf?src-supplier=Avnet; ComponentLink18URL: https://datasheet.ciiva.com/9049/dcrcwe3-9049146.pdf?src-supplier=Avnet; ComponentLink19Description: https://datasheet.ciiva.com/2469/dcrcwe3-2469444.pdf?src-supplier=Digi-Key; ComponentLink19URL: https://datasheet.ciiva.com/2469/dcrcwe3-2469444.pdf?src-supplier=Digi-Key; ComponentLink20Description: https://datasheet.ciiva.com/22702/1697337-22702917.pdf?src-supplier=Element14; ComponentLink20URL: https://datasheet.ciiva.com/22702/1697337-22702917.pdf?src-supplier=Element14; ComponentLink21Description: https://datasheet.ciiva.com/22674/1847332-22674633.pdf?src-supplier=Element14; ComponentLink21URL: https://datasheet.ciiva.com/22674/1847332-22674633.pdf?src-supplier=Element14; ComponentLink22Description: https://datasheet.ciiva.com/9049/dcrcwe3-9049166.pdf?src-supplier=Avnet; ComponentLink22URL: https://datasheet.ciiva.com/9049/dcrcwe3-9049166.pdf?src-supplier=Avnet; ComponentLink23Description: https://datasheet.ciiva.com/16202/dcrcwe3-16202110.pdf?src-supplier=Future+Electronics; ComponentLink23URL: https://datasheet.ciiva.com/16202/dcrcwe3-16202110.pdf?src-supplier=Future+Electronics; ComponentLink24Description: https://datasheet.ciiva.com/16774/70201119-16774127.pdf?src-supplier=Allied+Electronics; ComponentLink24URL: https://datasheet.ciiva.com/16774/70201119-16774127.pdf?src-supplier=Allied+Electronics; ComponentLink25Description: https://datasheet.ciiva.com/2469/dcrcwe3-2469445.pdf?src-supplier=Digi-Key; ComponentLink25URL: https://datasheet.ciiva.com/2469/dcrcwe3-2469445.pdf?src-supplier=Digi-Key; Composition: Thick Film; Contact Plating: Tin; Depth: 1.6mm; Features: Automotive AEC-Q200; Height: 0.024inch; Lead Free: Lead Free; Length: 3.2mm; Library Name: LHA_LIB.SchLib; Max Operating Temperature: 155°C; Military Standard: Not; Min Operating Temperature: -55°C; Number of Pins: 2; Number of Terminations: 2; Package Quantity: 10; PackageCase: 1206; Packaging: Tape and Reel; Power Rating: 250mW; Pricing 1: 5000=0.00904, 10000=0.00811, 25000=0.00745, 50000=0.00724 (USD); Radiation Hardening: No; REACH SVHC: Unknown; Resistance: 10.2kR; RoHS: Yes; Stock 1: 10000; Supplier 1: Digi-Key; Supplier Part Number 1: 541-10.2KFTR-ND; Termination: SMD/SMT; Tolerance: 1%; Weight: 0.000353oz; Width: 1.6mm
R21 = RES1: Resistor 1%; Manufacturer: Yageo; Manufacturer Part Number: RT1206FRE0715KL; Part Description: RES 15kR 1% 1206 250mW; LHADescription: RES SMD 15K OHM 1% 1/4W 1206; Max Power Dissipation: 250mW; Temperature Coefficient: 50ppm/°C; Voltage Rating: 200V; Case Code (Imperial): 1206; Case Code (Metric): 3216; Category: Resistors; Component Kind: Standard; ComponentLink1Description: https://datasheet.ciiva.com/2566/pyu-rt-1-to-0-05-rohs-l-5-2566519.pdf?src-supplier=Digi-Key; ComponentLink1URL: https://datasheet.ciiva.com/2566/pyu-rt-1-to-0-05-rohs-l-5-2566519.pdf?src-supplier=Digi-Key; ComponentLink2Description: https://datasheet.ciiva.com/8890/pyu-rt-1-to-0-05-rohs-l-4-8890776.pdf?src-supplier=Avnet; ComponentLink2URL: https://datasheet.ciiva.com/8890/pyu-rt-1-to-0-05-rohs-l-4-8890776.pdf?src-supplier=Avnet; ComponentLink3Description: https://datasheet.ciiva.com/12695/pyu-rt-1-to-0-05-rohs-l-4-12695256.pdf?src-supplier=Mouser; ComponentLink3URL: https://datasheet.ciiva.com/12695/pyu-rt-1-to-0-05-rohs-l-4-12695256.pdf?src-supplier=Mouser; ComponentLink6Description: https://datasheet.ciiva.com/7857/444357-7857254.pdf?src-supplier=Element14; ComponentLink6URL: https://datasheet.ciiva.com/7857/444357-7857254.pdf?src-supplier=Element14; ComponentLink7Description: https://datasheet.ciiva.com/7857/444357-7857255.pdf?src-supplier=Element14; ComponentLink7URL: https://datasheet.ciiva.com/7857/444357-7857255.pdf?src-supplier=Element14; Construction: Rectangular; Contact Plating: Tin,Matte; Depth: 1.6mm; Height: 0.026inch; Lead Free: Lead Free; Length: 0.122inch; Library Name: LHA_LIB.SchLib; Max Operating Temperature: 125°C; Military Standard: Not; Min Operating Temperature: -55°C; Number of Terminations: 2; Package Quantity: 5000; PackageCase: 1206; Packaging: Tape and Reel; Power Rating: 250mW; Pricing 1: 1=0.14000, 10=0.12800, 100=0.04940, 1000=0.02174, 2500=0.01877 (USD); Radiation Hardening: No; Resistance: 15kR; Resistor Type: Thin Film; RoHS: Yes; RoHS Compliant: Yes; Series: RT; Size Code: 1206; Stock 1: 1; Supplier 1: Digi-Key; Supplier Part Number 1: 13-RT1206FRE0715KLCT-ND; Termination: SMD/SMT; Tolerance: 1%; Width: 0.063inch
R22 = RES5: See LHADescription; Manufacturer: Yageo; Manufacturer Part Number: RC0603FR-071ML; Part Description: RES 1MR 1% 0603 100mW; LHADescription: RES SMD 1M OHM 1% 1/10W 0603; Power Rating: 100mW; Temperature Coefficient: 100ppm/°C; Tolerance: 1%; Voltage - Rated: 50V; Case Code (Imperial): 0603; Case Code (Metric): 1608; Category: Resistors; Component Kind: Standard; ComponentLink1Description: http://www.yageo.com/documents/recent/PYu-RC_Group_51_RoHS_L_7.pdf; ComponentLink1URL: http://www.yageo.com/documents/recent/PYu-RC_Group_51_RoHS_L_7.pdf; ComponentLink2Description: https://datasheet.ciiva.com/11819/0900766b80f96399-11819295.pdf?src-supplier=RSComponents; ComponentLink2URL: https://datasheet.ciiva.com/11819/0900766b80f96399-11819295.pdf?src-supplier=RSComponents; ComponentLink3Description: https://datasheet.ciiva.com/7983/443971-7983614.pdf?src-supplier=Element14; ComponentLink3URL: https://datasheet.ciiva.com/7983/443971-7983614.pdf?src-supplier=Element14; ComponentLink4Description: https://datasheet.ciiva.com/12555/rc0603-272842-12555388.pdf?src-supplier=Mouser; ComponentLink4URL: https://datasheet.ciiva.com/12555/rc0603-272842-12555388.pdf?src-supplier=Mouser; Composition: Thick Film; Depth: 0.8mm; Height: 0.45mm; Lead Free: Lead Free; Length: 1.6mm; Max Operating Temperature: 155°C; Military Standard: Not; Min Operating Temperature: -55°C; Number of Pins: 2; Package Quantity: 5000; PackageCase: 0603; Packaging: Tape and Reel; Pricing 1: 5000=0.00274, 10000=0.00238, 25000=0.00209, 50000=0.00192, 125000=0.00188 (USD); REACH SVHC: No SVHC; Resistance: 1MR; RoHS: Yes; Stock 1: 8219219; Supplier 1: Digi-Key; Supplier Part Number 1: 311-1.00MHRTR-ND; Termination: SMD/SMT; Voltage Rating (DC): 50V; Width: 0.8mm
R23 = RES5: See LHADescription; Manufacturer: Yageo; Manufacturer Part Number: RC0603FR-0727KL; Part Description: RES 27kR 1% 0603 100mW; LHADescription: RES SMD 27K OHM 1% 1/10W 0603; Max Power Dissipation: 100mW; Temperature Coefficient: 100ppm/°C; Tolerance: 1%; Voltage - Rated: 50V; Working Voltage: 75V; Case Code (Imperial): 0603; Case Code (Metric): 1608; Category: Resistors; Component Kind: Standard; ComponentLink1Description: http://www.yageo.com/documents/recent/PYu-RC_Group_51_RoHS_L_7.pdf; ComponentLink1URL: http://www.yageo.com/documents/recent/PYu-RC_Group_51_RoHS_L_7.pdf; ComponentLink2Description: https://datasheet.ciiva.com/11808/0900766b80f96399-11808540.pdf?src-supplier=RSComponents; ComponentLink2URL: https://datasheet.ciiva.com/11808/0900766b80f96399-11808540.pdf?src-supplier=RSComponents; ComponentLink3Description: https://datasheet.ciiva.com/2453/pyu-r-int-thick-7-2453968.pdf?src-supplier=Digi-Key; ComponentLink3URL: https://datasheet.ciiva.com/2453/pyu-r-int-thick-7-2453968.pdf?src-supplier=Digi-Key; ComponentLink4Description: https://datasheet.ciiva.com/2453/pyu-r-int-thick-7-2453969.pdf?src-supplier=Digi-Key; ComponentLink4URL: https://datasheet.ciiva.com/2453/pyu-r-int-thick-7-2453969.pdf?src-supplier=Digi-Key; ComponentLink5Description: https://datasheet.ciiva.com/7746/443971-7746311.pdf?src-supplier=Element14; ComponentLink5URL: https://datasheet.ciiva.com/7746/443971-7746311.pdf?src-supplier=Element14; ComponentLink6Description: https://datasheet.ciiva.com/7746/443971-7746312.pdf?src-supplier=Element14; ComponentLink6URL: https://datasheet.ciiva.com/7746/443971-7746312.pdf?src-supplier=Element14; ComponentLink7Description: https://datasheet.ciiva.com/15159/getdatasheetpartid-45811-15159564.pdf?src-supplier=Verical; ComponentLink7URL: https://datasheet.ciiva.com/15159/getdatasheetpartid-45811-15159564.pdf?src-supplier=Verical; ComponentLink8Description: https://datasheet.ciiva.com/2453/pyu-r-int-thick-7-2453967.pdf?src-supplier=Digi-Key; ComponentLink8URL: https://datasheet.ciiva.com/2453/pyu-r-int-thick-7-2453967.pdf?src-supplier=Digi-Key; ComponentLink9Description: https://datasheet.ciiva.com/46412/443971-46412847.pdf?src-supplier=Element14; ComponentLink9URL: https://datasheet.ciiva.com/46412/443971-46412847.pdf?src-supplier=Element14; Composition: Thick Film; Depth: 0.8mm; Features: Moisture Resistant; Height: 0.022inch; Lead Free: Lead Free; Length: 0.063inch; Max Operating Temperature: 155°C; Military Standard: Not; Min Operating Temperature: -55°C; Number of Pins: 2; Number of Terminations: 2; Package Quantity: 1; PackageCase: 0603; Packaging: Tape and Reel; Power Rating: 100mW; Pricing 1: 5000=0.00274, 10000=0.00238, 25000=0.00209, 50000=0.00192, 125000=0.00188 (USD); REACH SVHC: No SVHC; Resistance: 27kR; RoHS: Yes; Stock 1: 3120000; Supplier 1: Digi-Key; Supplier Part Number 1: 311-27.0KHRTR-ND; Termination: SMD/SMT; Voltage Rating (DC): 50V; Width: 0.031inch
R24 = RES5: See LHADescription; Manufacturer: Yageo; Manufacturer Part Number: RC0603FR-071K8L; Part Description: RES 1.8kR 1% 0603 100mW; LHADescription: RES SMD 1.8K OHM 1% 1/10W 0603; Max Power Dissipation: 100mW; Temperature Coefficient: 100ppm/°C; Tolerance: 1%; Voltage - Rated: 50V; Case Code (Imperial): 0603; Case Code (Metric): 1608; Category: Resistors; Component Kind: Standard; ComponentLink1Description: http://www.yageo.com/documents/recent/PYu-RC_Group_51_RoHS_L_7.pdf; ComponentLink1URL: http://www.yageo.com/documents/recent/PYu-RC_Group_51_RoHS_L_7.pdf; ComponentLink2Description: https://datasheet.ciiva.com/11808/0900766b80f96399-11808105.pdf?src-supplier=RSComponents; ComponentLink2URL: https://datasheet.ciiva.com/11808/0900766b80f96399-11808105.pdf?src-supplier=RSComponents; ComponentLink3Description: https://datasheet.ciiva.com/2519/pyu-r-int-thick-7-2519189.pdf?src-supplier=Digi-Key; ComponentLink3URL: https://datasheet.ciiva.com/2519/pyu-r-int-thick-7-2519189.pdf?src-supplier=Digi-Key; ComponentLink4Description: https://datasheet.ciiva.com/7746/443971-7746277.pdf?src-supplier=Element14; ComponentLink4URL: https://datasheet.ciiva.com/7746/443971-7746277.pdf?src-supplier=Element14; ComponentLink5Description: https://datasheet.ciiva.com/2519/pyu-r-int-thick-7-2519190.pdf?src-supplier=Digi-Key; ComponentLink5URL: https://datasheet.ciiva.com/2519/pyu-r-int-thick-7-2519190.pdf?src-supplier=Digi-Key; ComponentLink6Description: https://datasheet.ciiva.com/7746/443971-7746278.pdf?src-supplier=Element14; ComponentLink6URL: https://datasheet.ciiva.com/7746/443971-7746278.pdf?src-supplier=Element14; ComponentLink7Description: https://datasheet.ciiva.com/12558/rc0603-272842-12558694.pdf?src-supplier=Mouser; ComponentLink7URL: https://datasheet.ciiva.com/12558/rc0603-272842-12558694.pdf?src-supplier=Mouser; ComponentLink8Description: https://datasheet.ciiva.com/2519/pyu-r-int-thick-7-2519191.pdf?src-supplier=Digi-Key; ComponentLink8URL: https://datasheet.ciiva.com/2519/pyu-r-int-thick-7-2519191.pdf?src-supplier=Digi-Key; Composition: Thick Film; Depth: 0.8mm; Features: Moisture Resistant; Height: 0.45mm; Lead Free: Lead Free; Length: 1.6mm; Max Operating Temperature: 155°C; Military Standard: Not; Min Operating Temperature: -55°C; Number of Pins: 2; Number of Terminations: 2; Package Quantity: 5000; PackageCase: 0603; Packaging: Tape and Reel; Power Rating: 100mW; Pricing 1: 5000=0.00274, 10000=0.00238, 25000=0.00209, 50000=0.00192, 125000=0.00188 (USD); REACH SVHC: No SVHC; Resistance: 1.8kR; RoHS: Yes; Stock 1: 1970000; Supplier 1: Digi-Key; Supplier Part Number 1: 311-1.80KHRTR-ND; Termination: SMD/SMT; Voltage Rating (DC): 50V; Width: 0.8mm
